# Altium schematic sheet 02_POWER_SUPPLY.SchDoc(02_POWER_SUPPLY)
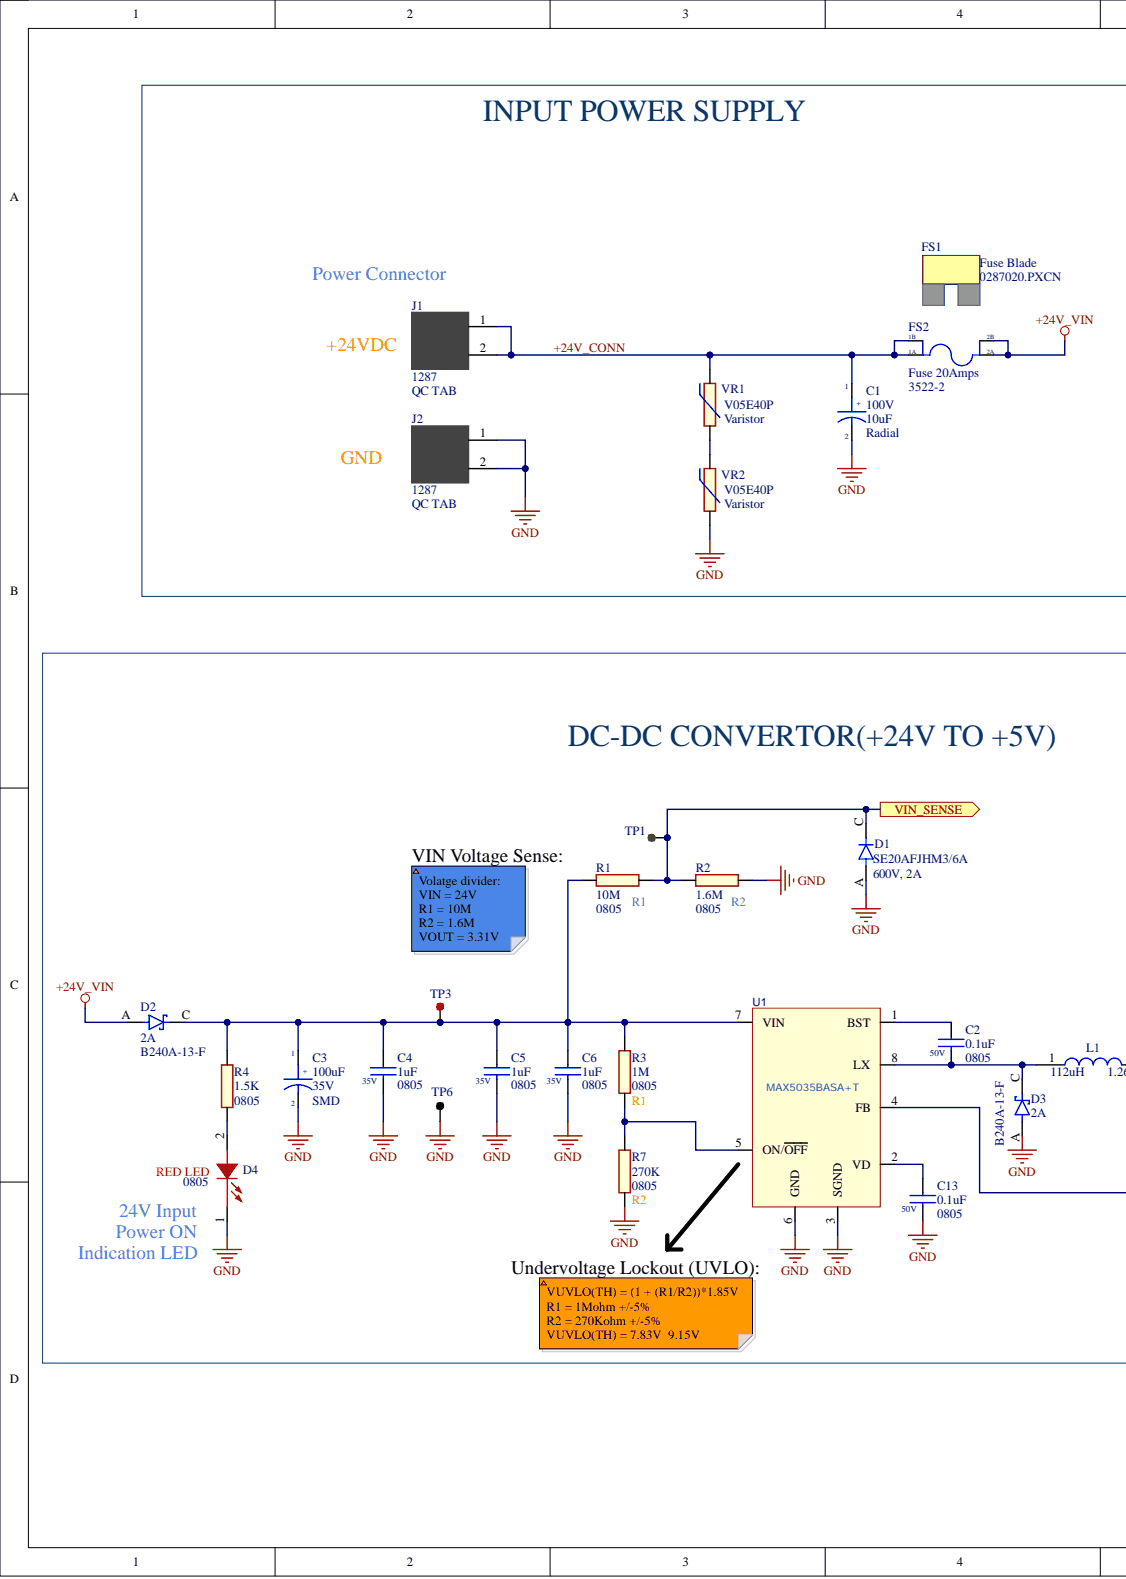
[diagram p0: 02_POWER_SUPPLY.SchDoc, left]
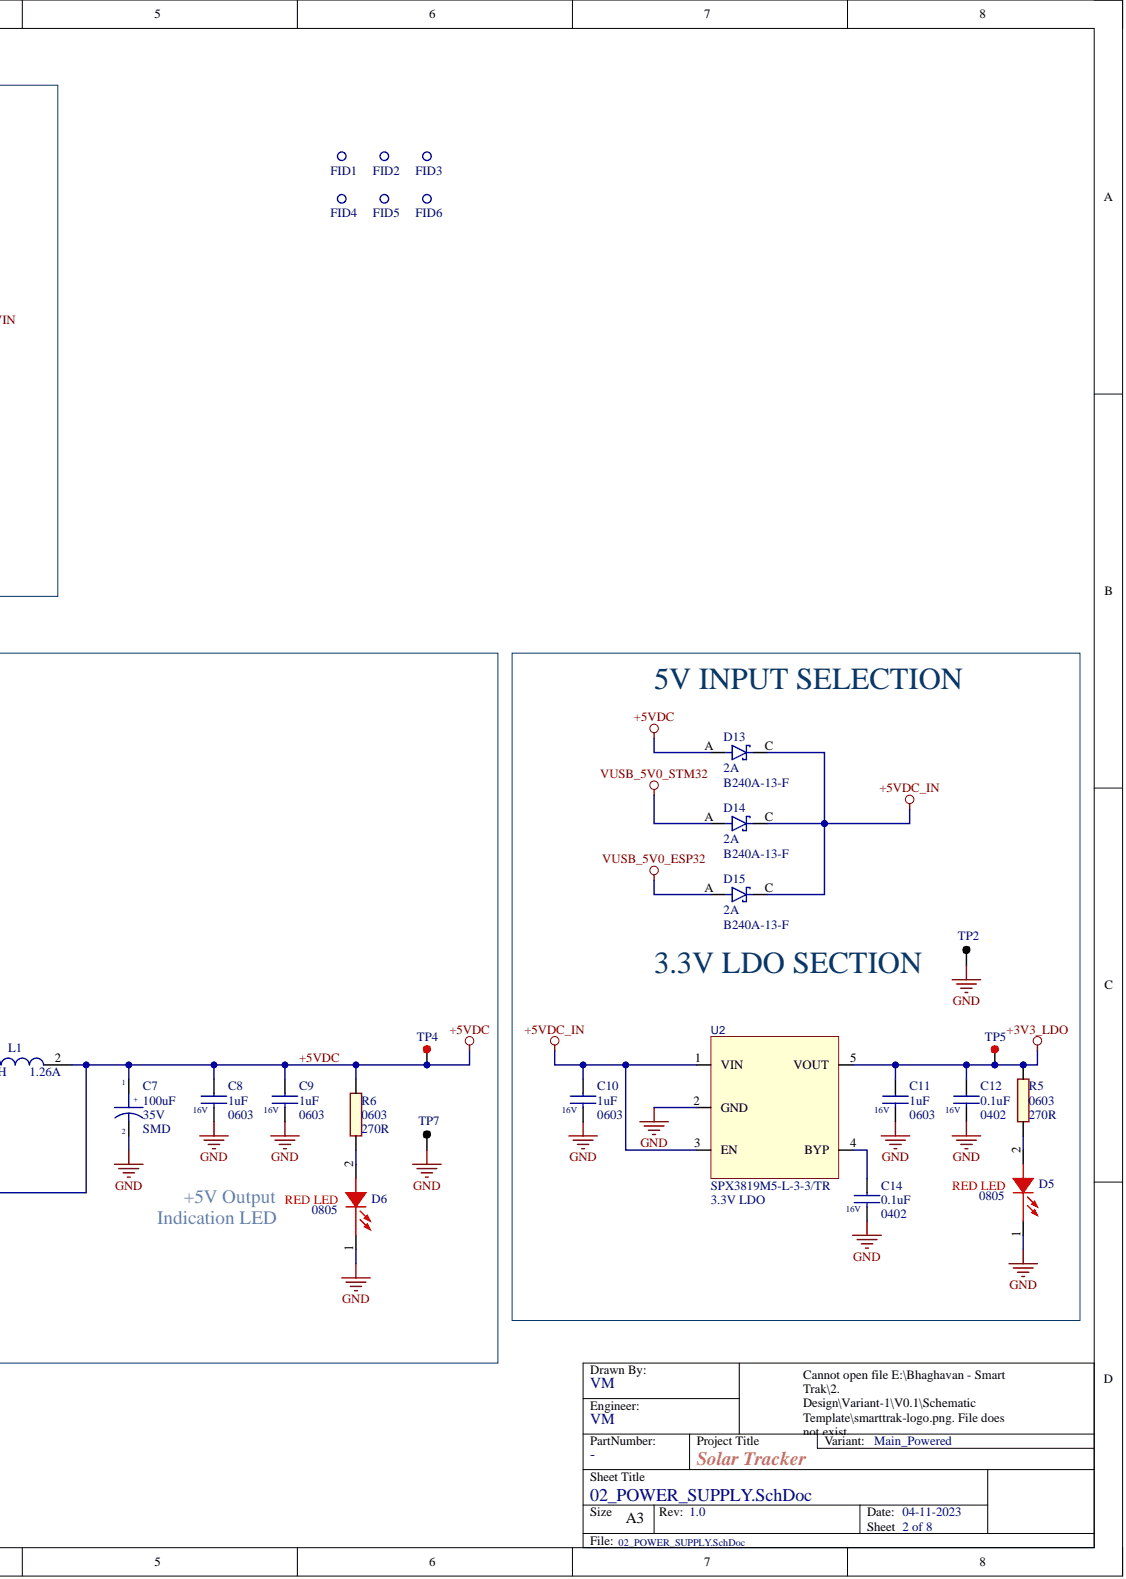
[diagram p1: 02_POWER_SUPPLY.SchDoc, right]

## Parts
C1  value 10uF  Note: Radial  Voltage - Rated: 100V  [CAP_ESK106M100AE3-HORI]  (hidden comment: CAP_ELEC_10uF_100V_RADIAL)
C2  value 0.1uF  Note: 0805  Voltage - Rated: 50V  [C0805]  (hidden comment: CAP_0.1uF_0805_50V)
C3  value 100uF  Note: SMD  Voltage - Rated: 35V  [CAPAE830X1050N]  (hidden comment: CAP_ELEC_100uF_35V_SMD)
C4  value 1uF  Note: 0805  Voltage - Rated: 35V  [C0805]  (hidden comment: CAP_1uF_0805_35V)
C5  value 1uF  Note: 0805  Voltage - Rated: 35V  [C0805]  (hidden comment: CAP_1uF_0805_35V)
C6  value 1uF  Note: 0805  Voltage - Rated: 35V  [C0805]  (hidden comment: CAP_1uF_0805_35V)
C7  value 100uF  Note: SMD  Voltage - Rated: 35V  [CAPAE830X1050N]  (hidden comment: CAP_ELEC_100uF_35V_SMD)
C8  value 1uF  Note: 0603  Voltage - Rated: 16V  [C0805]  (hidden comment: CAP_1uF_0603_16V)
C9  value 1uF  Note: 0603  Voltage - Rated: 16V  [C0805]  (hidden comment: CAP_1uF_0603_16V)
C10  value 1uF  Note: 0603  Voltage - Rated: 16V  [C0805]  (hidden comment: CAP_1uF_0603_16V)
C11  value 1uF  Note: 0603  Voltage - Rated: 16V  [C0805]  (hidden comment: CAP_1uF_0603_16V)
C12  value 0.1uF  Note: 0402  Voltage - Rated: 16V  [C0402]  (hidden comment: CAP_0.1uF_0402_16V)
C13  value 0.1uF  Note: 0805  Voltage - Rated: 50V  [C0805]  (hidden comment: CAP_0.1uF_0805_50V)
C14  value 0.1uF  Note: 0402  Voltage - Rated: 16V  [C0402]  (hidden comment: CAP_0.1uF_0402_16V)
D1  value SE20AFJHM3/6A  MPN: SE20AFJHM3/6A  Note: 600V, 2A  [SOD5226X100N_2A]  (hidden comment: DIODE_SE20AFJHM3/6A)
D2  value B240A-13-F  MPN: B240A-13-F  Note: 2A  [SOD5226X230N_2A]  (hidden comment: DIODE_SCHOTTKY_B240A-13-F)
D3  value B240A-13-F  MPN: B240A-13-F  Note: 2A  [SOD5226X230N_2A]  (hidden comment: DIODE_SCHOTTKY_B240A-13-F)
D4  value RED LED  Note: 0805  [LED0805_2A]  (hidden comment: LED_RED_0805)
D5  value RED LED  Note: 0805  [LED0805_2A]  (hidden comment: LED_RED_0805)
D6  value RED LED  Note: 0805  [LED0805_2A]  (hidden comment: LED_RED_0805)
D13  Note: 2A  [SOD5226X230N_2A]  (hidden comment: DIODE_SCHOTTKY_B240A-13-F)
D14  value B240A-13-F  MPN: B240A-13-F  Note: 2A  [SOD5226X230N_2A]  (hidden comment: DIODE_SCHOTTKY_B240A-13-F)
D15  value B240A-13-F  MPN: B240A-13-F  Note: 2A  [SOD5226X230N_2A]  (hidden comment: DIODE_SCHOTTKY_B240A-13-F)
FID1  Fiducial  [FIDUCIAL_40C]  (value not drawn)  (no BOM)
FID2  Fiducial  [FIDUCIAL_40C]  (value not drawn)  (no BOM)
FID3  Fiducial  [FIDUCIAL_40C]  (value not drawn)  (no BOM)
FID4  Fiducial  [FIDUCIAL_40C]  (value not drawn)  (no BOM)
FID5  Fiducial  [FIDUCIAL_40C]  (value not drawn)  (no BOM)
FID6  Fiducial  [FIDUCIAL_40C]  (value not drawn)  (no BOM)
FS1  value 0287020.PXCN  MPN: 0287020.PXCN  Note: Fuse Blade  (hidden comment: FUSE_BLADE_0287020.PXCN)  (mechanical)
FS2  value 3522-2  MPN: 3522-2  Note: Fuse 20Amps  [FUSEHOLDER_3522-2]  (hidden comment: FUSE_BLADE_CLIP_3522-2)
J1  QC_TAB_1287  value 1287  MPN: 1287  Note: QC TAB  [KEYSTONE_1287]
J2  QC_TAB_1287  value 1287  MPN: 1287  Note: QC TAB  [KEYSTONE_1287]
L1  value 112uH  Note: 1.26A  [IND_PE-53829SNL]  (hidden comment: IND_112uH_PE-53829SNL)
R1  value 10M  Note: 0805  [R0805]  (hidden comment: RES_10M_0805)
R2  value 1.6M  Note: 0805  [R0805]  (hidden comment: RES_1.6M_0805)
R3  value 1M  Note: 0805  [R0805]  (hidden comment: RES_1M_0805)
R4  value 1.5K  Note: 0805  [R0805]  (hidden comment: RES_1.5K_0805)
R5  value 270R  Note: 0603  [R0603]  (hidden comment: RES_270R_0603)
R6  value 270R  Note: 0603  [R0603]  (hidden comment: RES_270R_0603)
R7  value 270K  Note: 0805  [R0805]  (hidden comment: RES_270K_0805)
TP1  TP_GREEN_5121  [KEYSTONE_5121]  (value not drawn)
TP2  TP_BLACK_5006  [KEYSTONE_5006]  (value not drawn)
TP3  TP_RED_5005  [KEYSTONE_5005]  (value not drawn)
TP4  TP_RED_5005  [KEYSTONE_5005]  (value not drawn)
TP5  TP_RED_5005  [KEYSTONE_5005]  (value not drawn)
TP6  TP_BLACK_5006  [KEYSTONE_5006]  (value not drawn)
TP7  TP_BLACK_5006  [KEYSTONE_5006]  (value not drawn)
U1  value MAX5035BASA+T  MPN: MAX5035BASA+T  [SOIC127P600X175-8N]  (hidden comment: REG_MAX5035BASA+T)
U2  value SPX3819M5-L-3-3/TR  MPN: SPX3819M5-L-3-3/TR  Note: 3.3V LDO  [SOT95P280X145-5N]  (hidden comment: REG_LDO_SPX3819M5-L-3-3/TR)
VR1  value V05E40P  MPN: V05E40P  Note: Varistor  [VAR_V05E40P]  (hidden comment: VARISTOR_V05E40P)
VR2  value V05E40P  MPN: V05E40P  Note: Varistor  [VAR_V05E40P]  (hidden comment: VARISTOR_V05E40P)

## Ports
VIN_SENSE

## Nets
+3V3_LDO: C11.1 C12.1 R5.2 TP5.1 U2.5(VOUT)
+5VDC_IN: C10.1 D13.C D14.C D15.C U2.1(VIN) U2.3(EN)
+24V_VIN: D2.A FS2.2A FS2.2B
GND: C1.2 C3.2 C4.2 C5.2 C6.2 C7.2 C8.2 C9.2 C10.2 C11.2 C12.2 C13.2 C14.2 D1.A D3.A D4.1 D5.1 D6.1 J2.1 J2.2 R2.1 R7.1 TP2.1 TP6.1 TP7.1 U1.3(SGND) U1.6(GND) U2.2(GND) VR2.2
VUSB_5V0_ESP32: D15.A
VUSB_5V0_STM32: D14.A
+5VDC: C7.1 C8.1 C9.1 D13.A L1.2 R6.2 TP4.1 U1.4(FB)
+24V_CONN: C1.1 FS2.1A FS2.1B J1.1 J1.2 VR1.1
VIN_SENSE: D1.C R1.1 R2.2 TP1.1 ; port VIN_SENSE
NetC2_1: C2.1 U1.1(BST)
NetC2_2: C2.2 D3.C L1.1 U1.8(LX)
NetC3_1: C3.1 C4.1 C5.1 C6.1 D2.C R1.2 R3.2 R4.2 TP3.1 U1.7(VIN)
NetC13_1: C13.1 U1.2(VD)
NetC14_1: C14.1 U2.4(BYP)
NetD4_2: D4.2 R4.1
NetD5_2: D5.2 R5.1
NetD6_2: D6.2 R6.1
NetR3_1: R3.1 R7.2 U1.5(ON/OFF)
NetVR1_2: VR1.2 VR2.1

## Library data of the parts
C1 = CAP_ELEC_10uF_100V_RADIAL: CAP ALUM 10uF 20% 100V RADIAL; Manufacturer: KEMET; MPN: ESK106M100AE3; Component Kind: Standard; Library Name: Project.SchLib
C2 C13 = CAP_0.1uF_0805_50V: CAP CER 0.1UF 50V X7R 0805; Manufacturer: KEMET; MPN: C0805C104K5RAC7800; Component Kind: Standard; Library Name: Project.SchLib
C3 C7 = CAP_ELEC_100uF_35V_SMD: CAP ALUM 100UF 20% 35V SMD; Manufacturer: Panasonic; MPN: EEE-FK1V101AP; Component Kind: Standard; Library Name: Project.SchLib
C4-C6 = CAP_1uF_0805_35V: CAP CER 1UF 35V X7R 0805; Manufacturer: TDK Corporation; MPN: CGA4J3X7R1V105K125AB; Component Kind: Standard; Library Name: Project.SchLib
C8-C11 = CAP_1uF_0603_16V: CAP CER 1UF 16V X5R 0603; Manufacturer: Yageo; MPN: CC0603KRX5R7BB105; Component Kind: Standard; Library Name: Project.SchLib
C12 C14 = CAP_0.1uF_0402_16V: CAP CER 0.1UF 16V X7R 0402; Manufacturer: Yageo; MPN: CC0402KRX7R7BB104; Component Kind: Standard; Library Name: Project.SchLib
D1 = DIODE_SE20AFJHM3/6A: DIODE GEN PURP 600V 2A DO221AC; Manufacturer: Vishay General Semiconductor; Component Kind: Standard; Library Name: Project.SchLib
D2 D3 D13-D15 = DIODE_SCHOTTKY_B240A-13-F: DIODE SCHOTTKY 40V 2A SMA; Manufacturer: Diodes Incorporated; Component Kind: Standard; Library Name: Project.SchLib
  D13: MPN: B240A-13-F; Value: B240A-13-F
D4-D6 = LED_RED_0805: LED RED DIFFUSED 2V 633nm 0805 SMD; Manufacturer: ams-OSRAM; MPN: LS R976-NR-1; Component Kind: Standard; Library Name: Project.SchLib
FID1-FID6 = Fiducial: Fiduclial; Library Name: Project.SchLib
FS1 = FUSE_BLADE_0287020.PXCN: FUSE AUTO 20A 32VDC BLADE ATO; Manufacturer: Littelfuse; Library Name: Project.SchLib
FS2 = FUSE_BLADE_CLIP_3522-2: FUSE CLIP BLADE 500V 20A PCB; Manufacturer: Keystone Electronics; Component Kind: Standard; Library Name: Project.SchLib
J1 J2 = QC_TAB_1287: CONN QC TAB 0.250 SOLDER; Manufacturer: Keystone Electronics; Component Kind: Standard; Library Name: Project.SchLib
L1 = IND_112uH_PE-53829SNL: FIXED IND 112UH 1.26A 300MOHM SM; Manufacturer: Pulse Electronics; Component Kind: Standard; Library Name: Project.SchLib; MPN: PE-53829SNL
R1 = RES_10M_0805: RES SMD 10M OHM 5% 1/8W 0805; Manufacturer: Panasonic; MPN: ERJ-6GEYJ106V; Component Kind: Standard; Library Name: Project.SchLib
R2 = RES_1.6M_0805: RES SMD 1.6M OHM 1% 1/8W 0805; Manufacturer: Panasonic; MPN: ERJ-6ENF1604V; Component Kind: Standard; Library Name: Project.SchLib
R3 = RES_1M_0805: RES SMD 1M OHM 5% 1/2W 0805; Manufacturer: Panasonic; MPN: ERJ-P06J105V; Component Kind: Standard; Library Name: Project.SchLib
R4 = RES_1.5K_0805: RES SMD 1.5K OHM 5% 1/2W 0805; Manufacturer: Panasonic; MPN: ERJ-P06J152V; Component Kind: Standard; Library Name: Project.SchLib
R5 R6 = RES_270R_0603: RES 270 OHM 5% 1/10W 0603; Manufacturer: Yageo; MPN: RC0603JR-07270RL; Component Kind: Standard; Library Name: Project.SchLib
R7 = RES_270K_0805: RES SMD 270K OHM 5% 1/2W 0805; Manufacturer: Panasonic; MPN: ERJ-P06J274V; Component Kind: Standard; Library Name: Project.SchLib
TP1 = TP_GREEN_5121: PC TEST POINT COMPACT GREEN; Manufacturer: Keystone Electronics; MPN: 5121; Value: 5121; Component Kind: Standard; Library Name: Project.SchLib; Note: -
TP2 TP6 TP7 = TP_BLACK_5006: PC TEST POINT COMPACT BLACK; Manufacturer: Keystone Electronics; MPN: 5006; Value: 5006; Component Kind: Standard; Library Name: Project.SchLib; Note: -
TP3-TP5 = TP_RED_5005: PC TEST POINT COMPACT RED; Manufacturer: Keystone Electronics; MPN: 5005; Value: 5005; Component Kind: Standard; Library Name: Project.SchLib; Note: -
U1 = REG_MAX5035BASA+T: IC REG BUCK 5V 1A 8SOIC; Manufacturer: Analog Devices / Maxim Integrated; Component Kind: Standard; Library Name: Project.SchLib
U2 = REG_LDO_SPX3819M5-L-3-3/TR: IC REG LINEAR 3.3V 500MA SOT23-5; Manufacturer: MaxLinear; Component Kind: Standard; Library Name: Project.SchLib
VR1 VR2 = VARISTOR_V05E40P: VARISTOR 68V 500A DISC 5MM; Manufacturer: Littelfuse; Component Kind: Standard; Library Name: Project.SchLib
